# Altium schematic sheet solenoid_drv.SchDoc
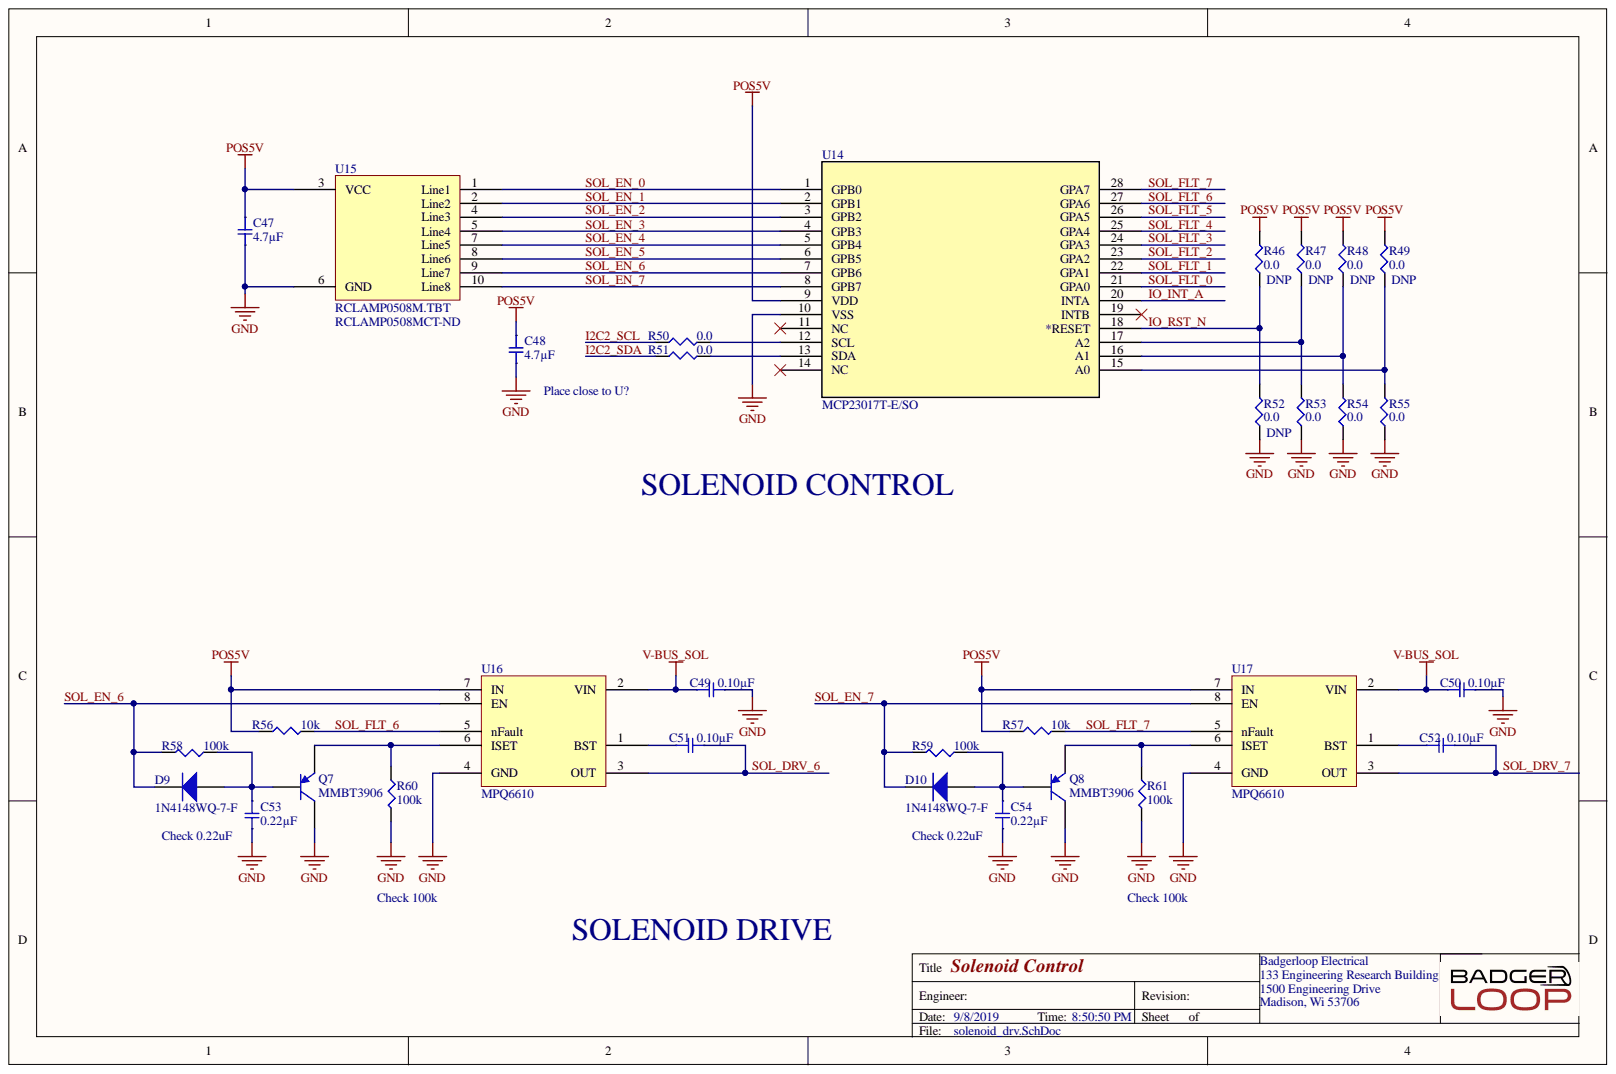
[diagram p0: solenoid_drv.SchDoc]

## Parts
C47  4.7µF  [SMD-0805C]
C48  4.7µF  [SMD-0805C]
C49  0.10µF  [SMD-0805C]
C50  0.10µF  [SMD-0805C]
C51  0.10µF  [SMD-0805C]
C52  0.10µF  [SMD-0805C]
C53  0.22µF  [SMD-0805C]
C54  0.22µF  [SMD-0805C]
D9  1N4148WQ-7-F  [INDM3838X18N]
D10  1N4148WQ-7-F  [INDM3838X18N]
Q7  MMBT3906  [SOTFL103P240X110-3N]
Q8  MMBT3906  [SOTFL103P240X110-3N]
R46  0.0  [SMD-0805-RES]
R47  0.0  [SMD-0805-RES]
R48  0.0  [SMD-0805-RES]
R49  0.0  [SMD-0805-RES]
R50  0.0  [SMD-0805-RES]
R51  0.0  [SMD-0805-RES]
R52  0.0  [SMD-0805-RES]
R53  0.0  [SMD-0805-RES]
R54  0.0  [SMD-0805-RES]
R55  0.0  [SMD-0805-RES]
R56  10k  [SMD-0805-RES]
R57  10k  [SMD-0805-RES]
R58  100k  [SMD-0805-RES]
R59  100k  [SMD-0805-RES]
R60  100k  [SMD-0805-RES]
R61  100k  [SMD-0805-RES]
U14  MCP23017T-E/SO  [SOIC28-W_MC-M]
U15  RCLAMP0508M.TBT  Supplier Part Number 1: RCLAMP0508MCT-ND  [SSOP50P490X175-10N]
U16  MPQ6610  [SOP127P600X175-8N]
U17  MPQ6610  [SOP127P600X175-8N]

## Nets
GND: C47.2 C48.2 C49.2 C50.2 C53.2 C54.2 Q7.3 Q8.3 R52.1 R53.1 R54.1 R55.1 R60.2 R61.2 U14.10(VSS) U15.6(GND) U16.4(GND) U17.4(GND)
POS5V: C47.1 C48.1 R46.2 R47.2 R48.2 R49.2 R56.1 R57.1 U14.9(VDD) U15.3(VCC) U16.7(IN) U17.7(IN)
V-BUS_SOL: C49.1 C50.1 U16.2(VIN) U17.2(VIN)
I2C2_SCL: R50.1
I2C2_SDA: R51.1
IO_INT_A: U14.20(INTA)
IO_RST_N: R46.1 R52.2 U14.18(*RESET)
SOL_DRV_6: C51.2 U16.3(OUT)
SOL_DRV_7: C52.2 U17.3(OUT)
SOL_EN_0: U14.1(GPB0) U15.1(Line1)
SOL_EN_1: U14.2(GPB1) U15.2(Line2)
SOL_EN_2: U14.3(GPB2) U15.4(Line3)
SOL_EN_3: U14.4(GPB3) U15.5(Line4)
SOL_EN_4: U14.5(GPB4) U15.7(Line5)
SOL_EN_5: U14.6(GPB5) U15.8(Line6)
SOL_EN_6: D9.2 R58.2 U14.7(GPB6) U15.9(Line7) U16.8(EN)
SOL_EN_7: D10.2 R59.2 U14.8(GPB7) U15.10(Line8) U17.8(EN)
SOL_FLT_0: U14.21(GPA0)
SOL_FLT_1: U14.22(GPA1)
SOL_FLT_2: U14.23(GPA2)
SOL_FLT_3: U14.24(GPA3)
SOL_FLT_4: U14.25(GPA4)
SOL_FLT_5: U14.26(GPA5)
SOL_FLT_6: R56.2 U14.27(GPA6) U16.5(nFault)
SOL_FLT_7: R57.2 U14.28(GPA7) U17.5(nFault)
NetC51_1: C51.1 U16.1(BST)
NetC52_1: C52.1 U17.1(BST)
NetC53_1: C53.1 D9.1 Q7.2 R58.1
NetC54_1: C54.1 D10.1 Q8.2 R59.1
NetQ7_1: Q7.1 R60.1 U16.6(ISET)
NetQ8_1: Q8.1 R61.1 U17.6(ISET)
NetR47_1: R47.1 R53.2 U14.17(A2)
NetR48_1: R48.1 R54.2 U14.16(A1)
NetR49_1: R49.1 R55.2 U14.15(A0)
NetR50_2: R50.2 U14.12(SCL)
NetR51_2: R51.2 U14.13(SDA)

Not connected: U14 11(NC) 14(NC) 19(INTB)

## Library data of the parts
C47 C48 = GRM21BR60J475KA11L: CAP CER 4.7UF 6.3V X5R 0805; Manufacturer: Murata Electronics North America; @ qty: 0; Minimum Quantity: 1; Tolerance: ±10%; Unit Price (USD): 0.28; Applications: General Purpose; Component Kind: Standard; Datasheets: http://www.murata.com/~/media/webrenewal/support/library/catalog/products/capacitor/mlcc/c02e.pdf; Digi-Key Part Number: 490-9749-1-ND; Factory Stock: 0; Features: -; Height - Seated (Max): -; Image: //media.digikey.com/Renders/Murata%20Renders/0805%20(2012%20Metric)%20.85mm.jpg; Lead Spacing: -; Lead Style: -; Library Name: capacitor.SchLib; Mounting Type: Surface Mount, MLCC; Operating Temperature: -55°C ~ 85°C; Package / Case: 0805 (2012 Metric); Packaging: Cut Tape (CT); Part Number: GRM21BR60J475KA11L; Part Status: Active; Quantity Available: 2821; Ratings: -; Series: GRM; Size / Dimension: 0.079" L x 0.049" W (2.00mm x 1.25mm); Temperature Coefficient: X5R; Thickness (Max): 0.055" (1.40mm); Value: 4.7µF; Voltage: 6.3V
C49-C52 = GRM21B7U1A104JA01L: CAP CER 0.1UF 10V U2J 0805; Manufacturer: Murata Electronics North America; @ qty: 0; Minimum Quantity: 1; Tolerance: ±5%; Unit Price (USD): 0.4; Applications: General Purpose; Component Kind: Standard; Datasheets: http://www.murata.com/~/media/webrenewal/support/library/catalog/products/capacitor/mlcc/c02e.pdf; Digi-Key Part Number: 490-11592-1-ND; Factory Stock: 0; Features: -; Height - Seated (Max): -; Image: //media.digikey.com/Renders/Murata%20Renders/0805%20(2012%20Metric)%20.85mm.jpg; Lead Spacing: -; Lead Style: -; Library Name: capacitors.SchLib; Mounting Type: Surface Mount, MLCC; Operating Temperature: -55°C ~ 125°C; Package / Case: 0805 (2012 Metric); Packaging: Cut Tape (CT); Part Number: GRM21B7U1A104JA01L; Part Status: Active; Quantity Available: 3390; Ratings: -; Series: GRM; Size / Dimension: 0.079" L x 0.049" W (2.00mm x 1.25mm); Temperature Coefficient: U2J; Thickness (Max): 0.053" (1.35mm); Value: 0.10µF; Voltage: 10V
C53 C54 = LLA215R71A224MA14L: CAP CER 0.22UF 10V X7R 0805; Manufacturer: Murata Electronics North America; @ qty: 0; Minimum Quantity: 1; Tolerance: ±20%; Unit Price (USD): 0.36; Applications: Bypass, Decoupling; Component Kind: Standard; Datasheets: http://www.murata.com/~/media/webrenewal/support/library/catalog/products/capacitor/mlcc/c02e.pdf; Digi-Key Part Number: 490-4432-1-ND; Factory Stock: 0; Features: Low ESL (Multi-Terminal); Height - Seated (Max): -; Image: //media.digikey.com/Renders/Murata%20Renders/0805-LLA.jpg; Lead Spacing: -; Lead Style: -; Library Name: capacitors.SchLib; Mounting Type: Surface Mount, MLCC; Operating Temperature: -55°C ~ 125°C; Package / Case: 0805 (2012 Metric); Packaging: Cut Tape (CT); Part Number: LLA215R71A224MA14L; Part Status: Active; Quantity Available: 9914; Ratings: -; Series: LLA; Size / Dimension: 0.079" L x 0.049" W (2.00mm x 1.25mm); Temperature Coefficient: X7R; Thickness (Max): 0.022" (0.55mm); Value: 0.22µF; Voltage: 10V
D9 D10 = 1N4148WQ-7-F: Diodes - General Purpose, Power, Switching SMT Fast Switching Diode; Manufacturer: Diodes Inc.; Component Kind: Standard; Digikey Link: https://www.digikey.com/product-detail/en/diodes-incorporated/1N4148WQ-7-F/1N4148WQ-7-FDITR-ND/8136126; Digikey P/N: 1N4148WQ-7-FDITR-ND; Library Name: misc.SchLib; Manufacturer P/N: 1N4148WQ-7-F
Q7 Q8 = MMBT3906-7-F: Manufacturer: Diodes Zetex; Collector Base Voltage (VCBO): 40V; Collector Emitter Saturation Voltage: -400mV; Continuous Collector Current: -200mA; Element Configuration: Single; Emitter Base Voltage (VEBO): 6V; Frequency: 250MHz; hFE Min: 100; Max Collector Current: 200mA; Max Power Dissipation: 300mW; Number of Elements: 1; Polarity: PNP; Voltage Rating (DC): -40V; Case/Package: SOT-23; Collector Emitter Breakdown Voltage: 40V; Collector Emitter Voltage (VCEO): 40V; Component Kind: Standard; ComponentLink1Description: https://datasheet.ciiva.com/27052/1540746-27052408.pdf?src-supplier=Jameco; ComponentLink1URL: https://datasheet.ciiva.com/27052/1540746-27052408.pdf?src-supplier=Jameco; ComponentLink2Description: https://datasheet.ciiva.com/15139/getdatasheetpartid-255292-15139821.pdf?src-supplier=Verical; ComponentLink2URL: https://datasheet.ciiva.com/15139/getdatasheetpartid-255292-15139821.pdf?src-supplier=Verical; Contact Plating: Tin,Matte; Current Rating: -200mA; Gain Bandwidth Product: 250MHz; Height: 1mm; Lead Free: Lead Free; Length: 3.05mm; Library Name: misc.SchLib; Manufacturer Part Number: MMBT3906-7-F; Max Breakdown Voltage: 40V; Max Frequency: 250MHz; Max Operating Temperature: 150°C; Min Operating Temperature: -55°C; Mount: Surface Mount; Number of Pins: 3; Package Quantity: 3000; Packaging: Tape and Reel; Power Dissipation: 300mW; Pricing 1: 1=0.12000, 10=0.11400, 100=0.06190, 500=0.03806, 1000=0.02596 (USD); Radiation Hardening: No; REACH SVHC: No SVHC; RoHS: Yes; Stock 1: 687580; Supplier 1: Digi-Key; Supplier Part Number 1: MMBT3906-FDICT-ND; Transition Frequency: 250MHz; Weight: 0.000282oz; Width: 1.4mm
R46-R55 = ERJ-6GEY0R00V: RES SMD 0.0 OHM JUMPER 1/8W 0805; Manufacturer: Panasonic Electronic Components; @ qty: 1500; Factory Stock: 0; Minimum Quantity: 1; Power (Watts): 0.125W, 1/8W; Unit Price (USD): 0.00544; Component Kind: Standard; Composition: Thick Film; Datasheets: http://industrial.panasonic.com/www-cgi/jvcr13pz.cgi?E+PZ+3+AOA0001+ERJ6GEY0R00V+7+WW; Digi-Key Part Number: P0.0ACT-ND; Failure Rate: -; Features: Automotive AEC-Q200; Height - Seated (Max): 0.028" (0.70mm); Image: //media.digikey.com/Renders/Panasonic%20Renders/ERJ-0805%20Pkg.jpg; Library Name: resistors.SchLib; Number of Terminations: 2; Operating Temperature: -55°C ~ 155°C; Package / Case: 0805 (2012 Metric); Packaging: Cut Tape (CT); Part Number: ERJ-6GEY0R00V; Part Status: Active; Quantity Available: 1597627; Series: ERJ; Size / Dimension: 0.079" L x 0.049" W (2.00mm x 1.25mm); Supplier Device Package: 0805; Temperature Coefficient: -; Tolerance: Jumper; Value: 0.0
  R46-R49 R52: DNP: DNP
R56 R57 = ERJ-P06J103V: RES SMD 10K OHM 5% 1/2W 0805; Manufacturer: Panasonic Electronic Components; @ qty: 1500; Factory Stock: 0; Minimum Quantity: 1; Power (Watts): 0.5W, 1/2W; Temperature Coefficient: ±200ppm/°C; Unit Price (USD): 0.01839; Component Kind: Standard; Composition: Thick Film; Datasheets: https://industrial.panasonic.com/cdbs/www-data/pdf/RDA0000/AOA0000C243.pdf; Digi-Key Part Number: P10KADCT-ND; Failure Rate: -; Features: Automotive AEC-Q200, Pulse Withstanding; Height - Seated (Max): 0.028" (0.70mm); Image: //media.digikey.com/Renders/Panasonic%20Renders/ERJ-0805%20Pkg.jpg; Library Name: resistors.SchLib; Number of Terminations: 2; Operating Temperature: -55°C ~ 155°C; Package / Case: 0805 (2012 Metric); Packaging: Cut Tape (CT); Part Number: ERJ-P06J103V; Part Status: Active; Quantity Available: 413576; Series: ERJ; Size / Dimension: 0.079" L x 0.049" W (2.00mm x 1.25mm); Supplier Device Package: 0805; Tolerance: ±5%; Value: 10k
R58-R61 = ERJ-P06J104V: RES SMD 100K OHM 5% 1/2W 0805; Manufacturer: Panasonic Electronic Components; @ qty: 1500; Factory Stock: 0; Minimum Quantity: 1; Power (Watts): 0.5W, 1/2W; Temperature Coefficient: ±200ppm/°C; Unit Price (USD): 0.01839; Component Kind: Standard; Composition: Thick Film; Datasheets: https://industrial.panasonic.com/cdbs/www-data/pdf/RDA0000/AOA0000C243.pdf; Digi-Key Part Number: P100KADCT-ND; Failure Rate: -; Features: Automotive AEC-Q200, Pulse Withstanding; Height - Seated (Max): 0.028" (0.70mm); Image: //media.digikey.com/Renders/Panasonic%20Renders/ERJ-0805%20Pkg.jpg; Library Name: resistors.SchLib; Number of Terminations: 2; Operating Temperature: -55°C ~ 155°C; Package / Case: 0805 (2012 Metric); Packaging: Cut Tape (CT); Part Number: ERJ-P06J104V; Part Status: Active; Quantity Available: 82264; Series: ERJ; Size / Dimension: 0.079" L x 0.049" W (2.00mm x 1.25mm); Supplier Device Package: 0805; Tolerance: ±5%; Value: 100k
U14 = MCP23017T-E/SO: IC I/O EXPANDER I2C 16B 28SOIC; Manufacturer: Microchip; Component Kind: Standard; Digikey Link: https://www.digikey.com/product-detail/en/microchip-technology/MCP23017T-E-SO/MCP23017T-E-SOCT-ND/5358289; Digikey P/N: MCP23017T-E/SOCT-ND; Library Name: ic.SchLib; Manufacturer P/N: MCP23017T-E/SO
U15 = RCLAMP0508M.TBT: TVS DIODE 5VWM 25VC 10MSOP; Manufacturer: Semtech; Breakdown Voltage: 6V; Capacitance: 3pF; Clamping Voltage: 25V; Direction: Unidirectional; Leakage Current: 5uA; Max Power Dissipation: 300W; Number of Bidirectional Channels: 8; Number of Elements: 1; Operating Supply Voltage: 5V; Peak Pulse Current: 12A; Power Line Protection: Yes; Test Current: 1mA; Case/Package: MSOP; Component Kind: Standard; ComponentLink1Description: https://datasheet.ciiva.com/339/rclamp0508m-339445.pdf?src-supplier=Digi-Key; ComponentLink1URL: https://datasheet.ciiva.com/339/rclamp0508m-339445.pdf?src-supplier=Digi-Key; ComponentLink2Description: https://datasheet.ciiva.com/339/rclamp0508m-339446.pdf?src-supplier=Digi-Key; ComponentLink2URL: https://datasheet.ciiva.com/339/rclamp0508m-339446.pdf?src-supplier=Digi-Key; ComponentLink3Description: https://datasheet.ciiva.com/8029/rclamp0508m-8029926.pdf?src-supplier=Element14; ComponentLink3URL: https://datasheet.ciiva.com/8029/rclamp0508m-8029926.pdf?src-supplier=Element14; ComponentLink4Description: https://datasheet.ciiva.com/339/rclamp0508m-339447.pdf?src-supplier=Digi-Key; ComponentLink4URL: https://datasheet.ciiva.com/339/rclamp0508m-339447.pdf?src-supplier=Digi-Key; ComponentLink5Description: https://datasheet.ciiva.com/16294/rclamp0508m-16294599.pdf?src-supplier=Future+Electronics; ComponentLink5URL: https://datasheet.ciiva.com/16294/rclamp0508m-16294599.pdf?src-supplier=Future+Electronics; ComponentLink6Description: https://datasheet.ciiva.com/5748/rclamp0508m-5748392.pdf?src-supplier=Farnell; ComponentLink6URL: https://datasheet.ciiva.com/5748/rclamp0508m-5748392.pdf?src-supplier=Farnell; ComponentLink7Description: https://datasheet.ciiva.com/11193/rclamp0508m-11193708.pdf?src-supplier=Avnet; ComponentLink7URL: https://datasheet.ciiva.com/11193/rclamp0508m-11193708.pdf?src-supplier=Avnet; ComponentLink8Description: https://datasheet.ciiva.com/25957/rclamp0508m-25957549.pdf?src-supplier=Avnet+Europe; ComponentLink8URL: https://datasheet.ciiva.com/25957/rclamp0508m-25957549.pdf?src-supplier=Avnet+Europe; Depth: 3.1mm; Lead Free: Lead Free; Length: 3.1mm; Library Name: ic.SchLib; Manufacturer Part Number: RCLAMP0508M.TBT; Max Breakdown Voltage: 6V; Max Operating Temperature: 125°C; Min Breakdown Voltage: 6V; Min Operating Temperature: -55°C; Mount: Surface Mount; Number of Channels: 8; Number of Pins: 10; Packaging: Tape and Reel; Peak Pulse Power: 300W; Polarity: Unidirectional; Pricing 1: 1=2.89000, 10=2.59300, 25=2.44640, 100=2.08420, 250=1.95700 (USD); Radiation Hardening: No; REACH SVHC: No SVHC; Reverse Breakdown Voltage: 6V; Reverse Standoff Voltage: 5V; RoHS: Yes; Stock 1: 4791; Supplier 1: Digi-Key; Termination: SMD/SMT; Voltage Rating (DC): 5V; Width: 3mm; Working Voltage: 5V
U16 U17 = MPQ6610: Component Kind: Standard; Library Name: ic.SchLib
